# Altium schematic sheet Sheet_2.schdoc
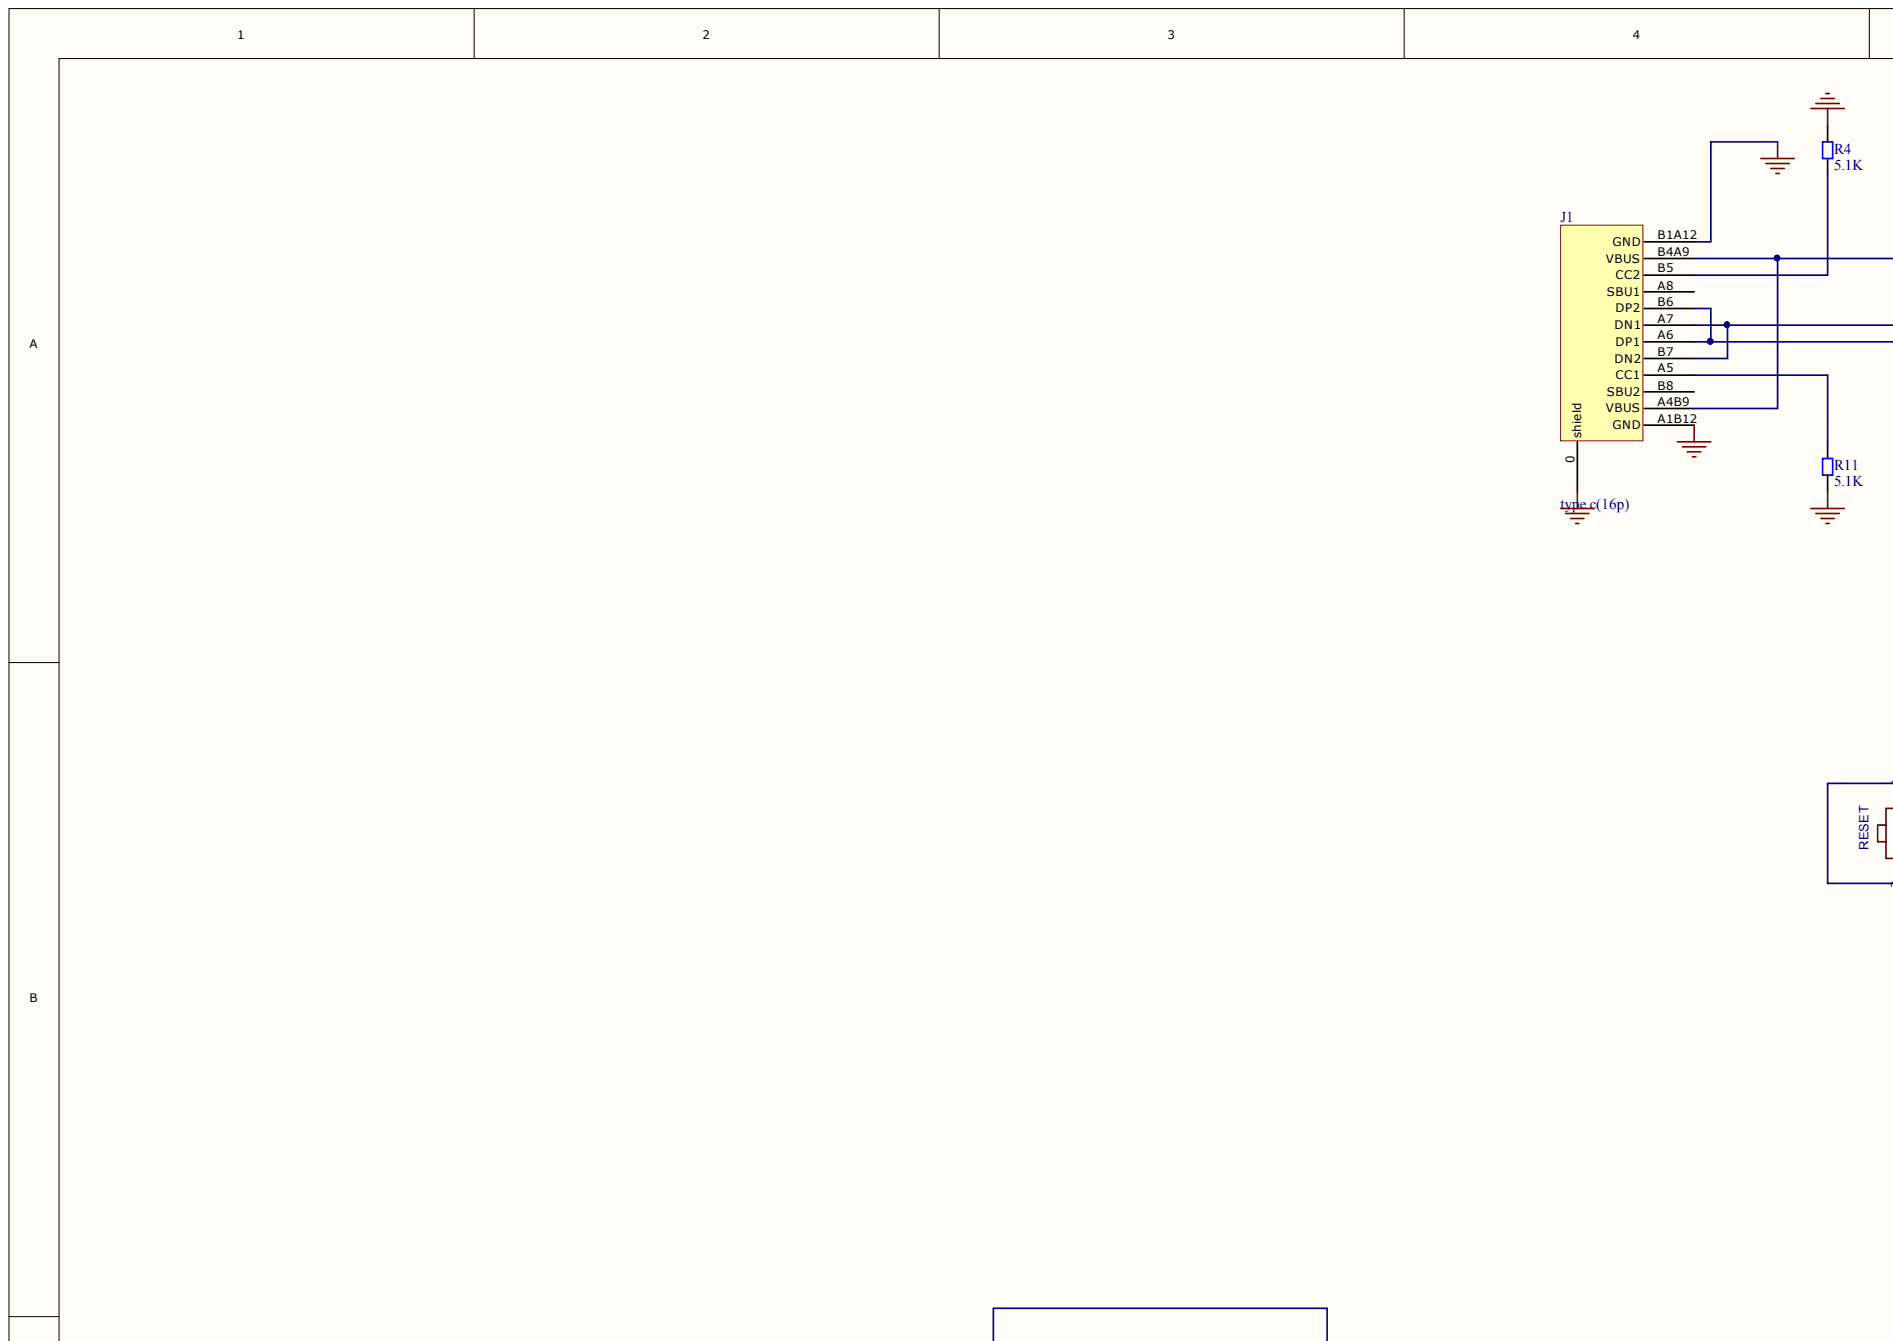
[diagram p0: Sheet_2.schdoc, top left]
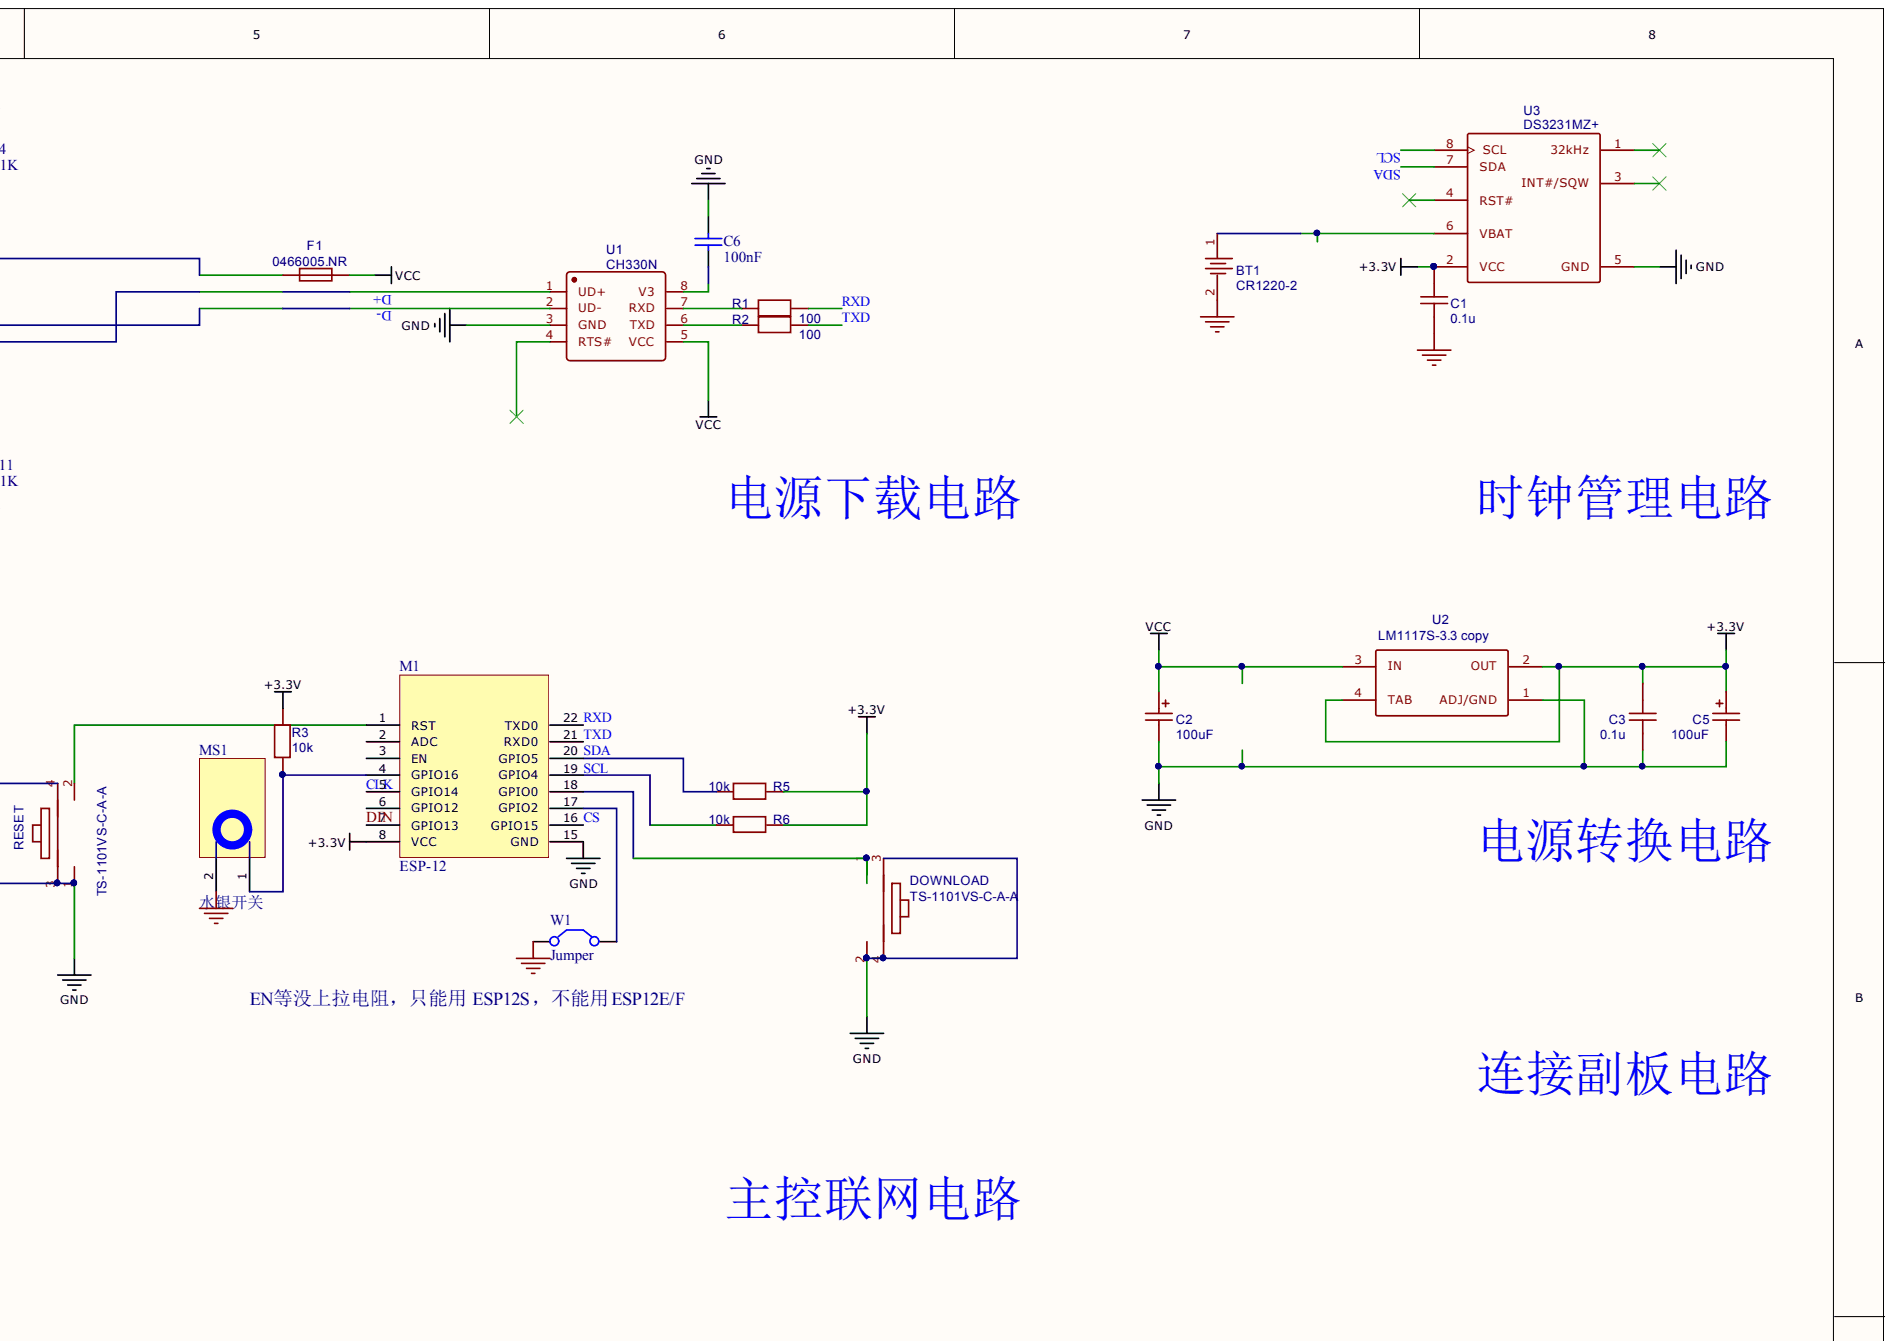
[diagram p1: Sheet_2.schdoc, top right]
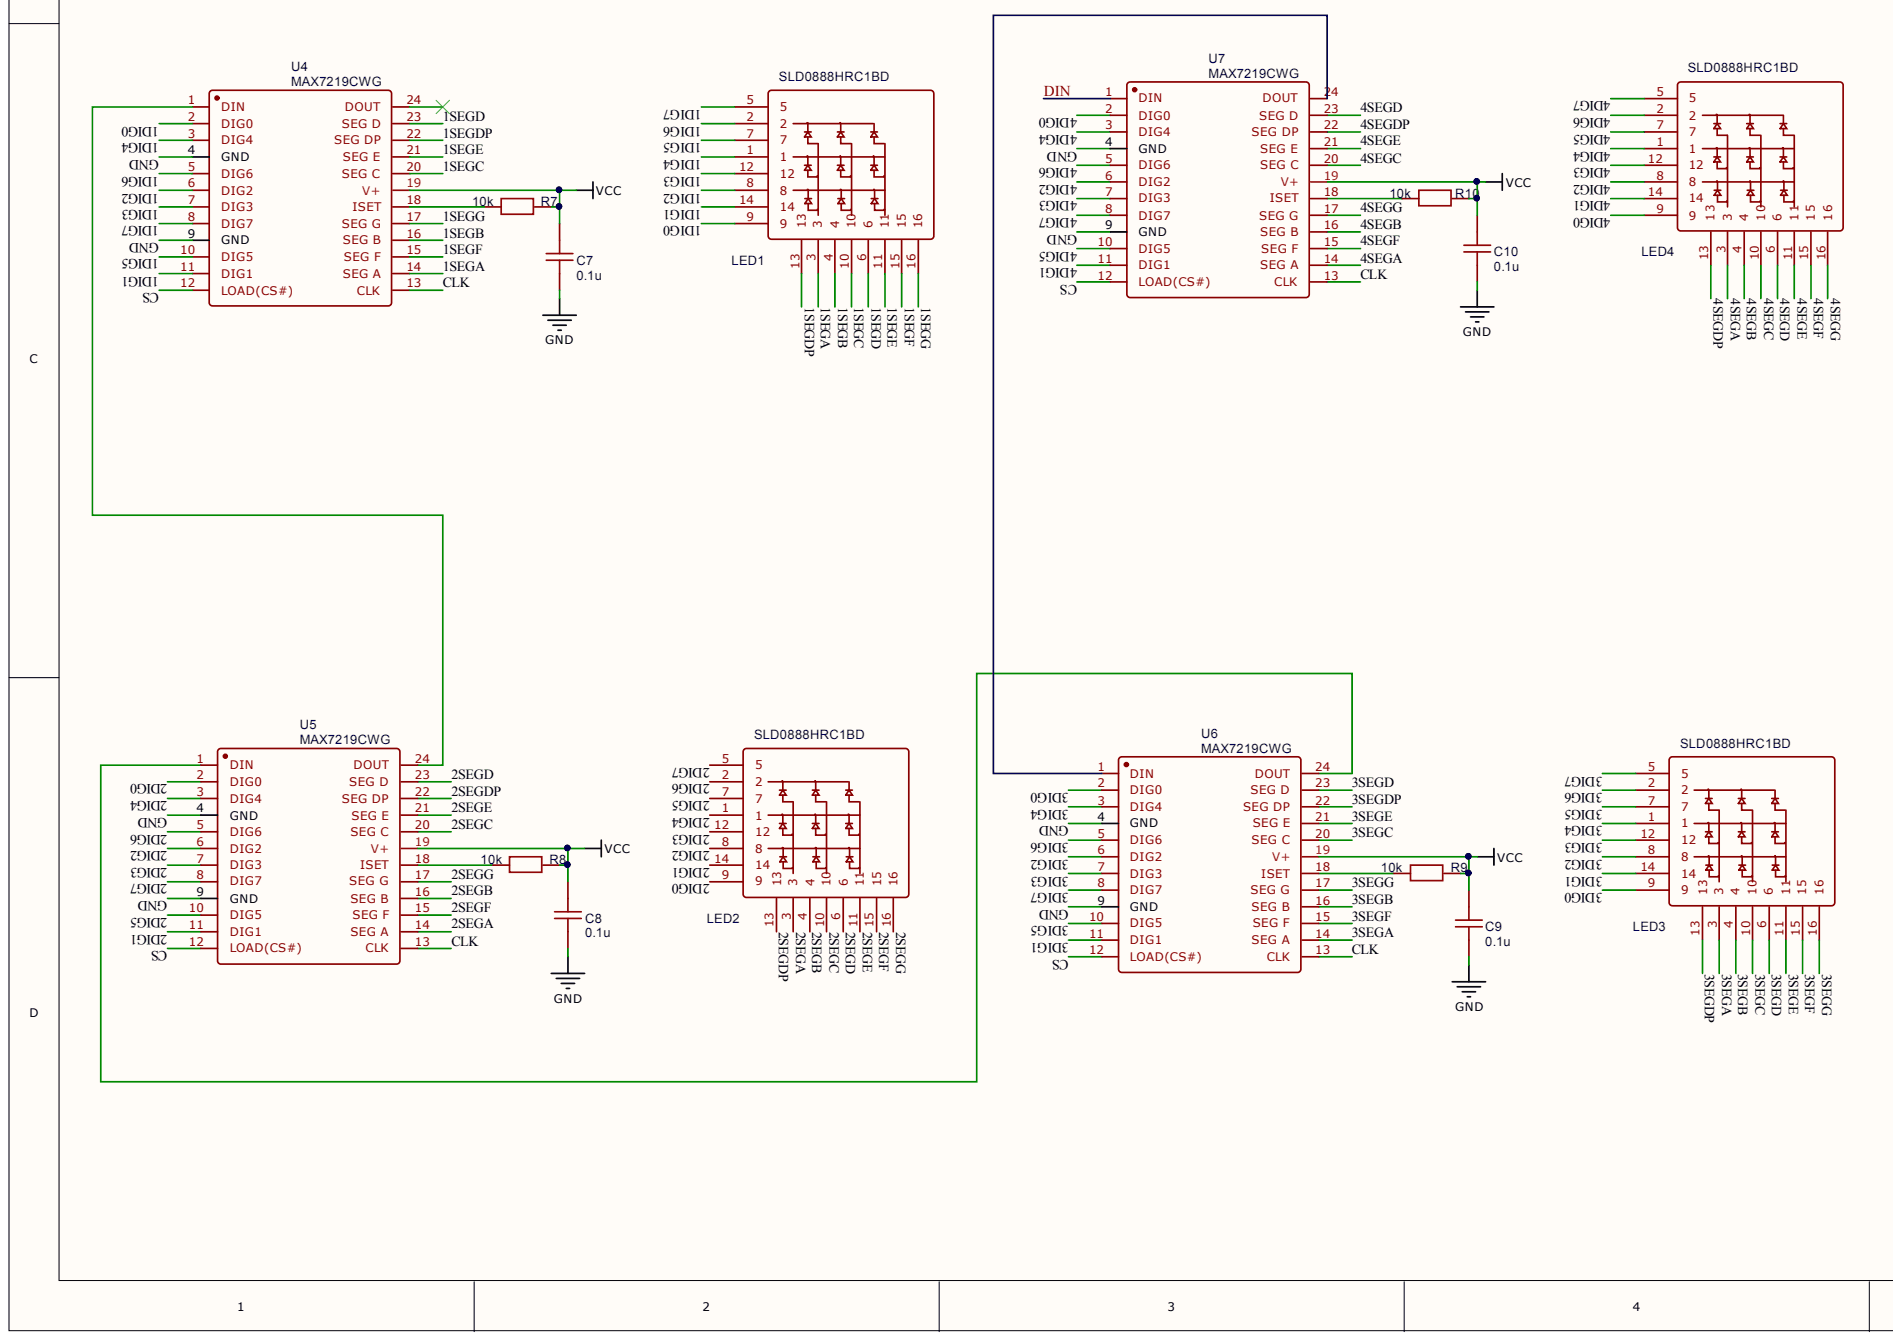
[diagram p2: Sheet_2.schdoc, bottom left]
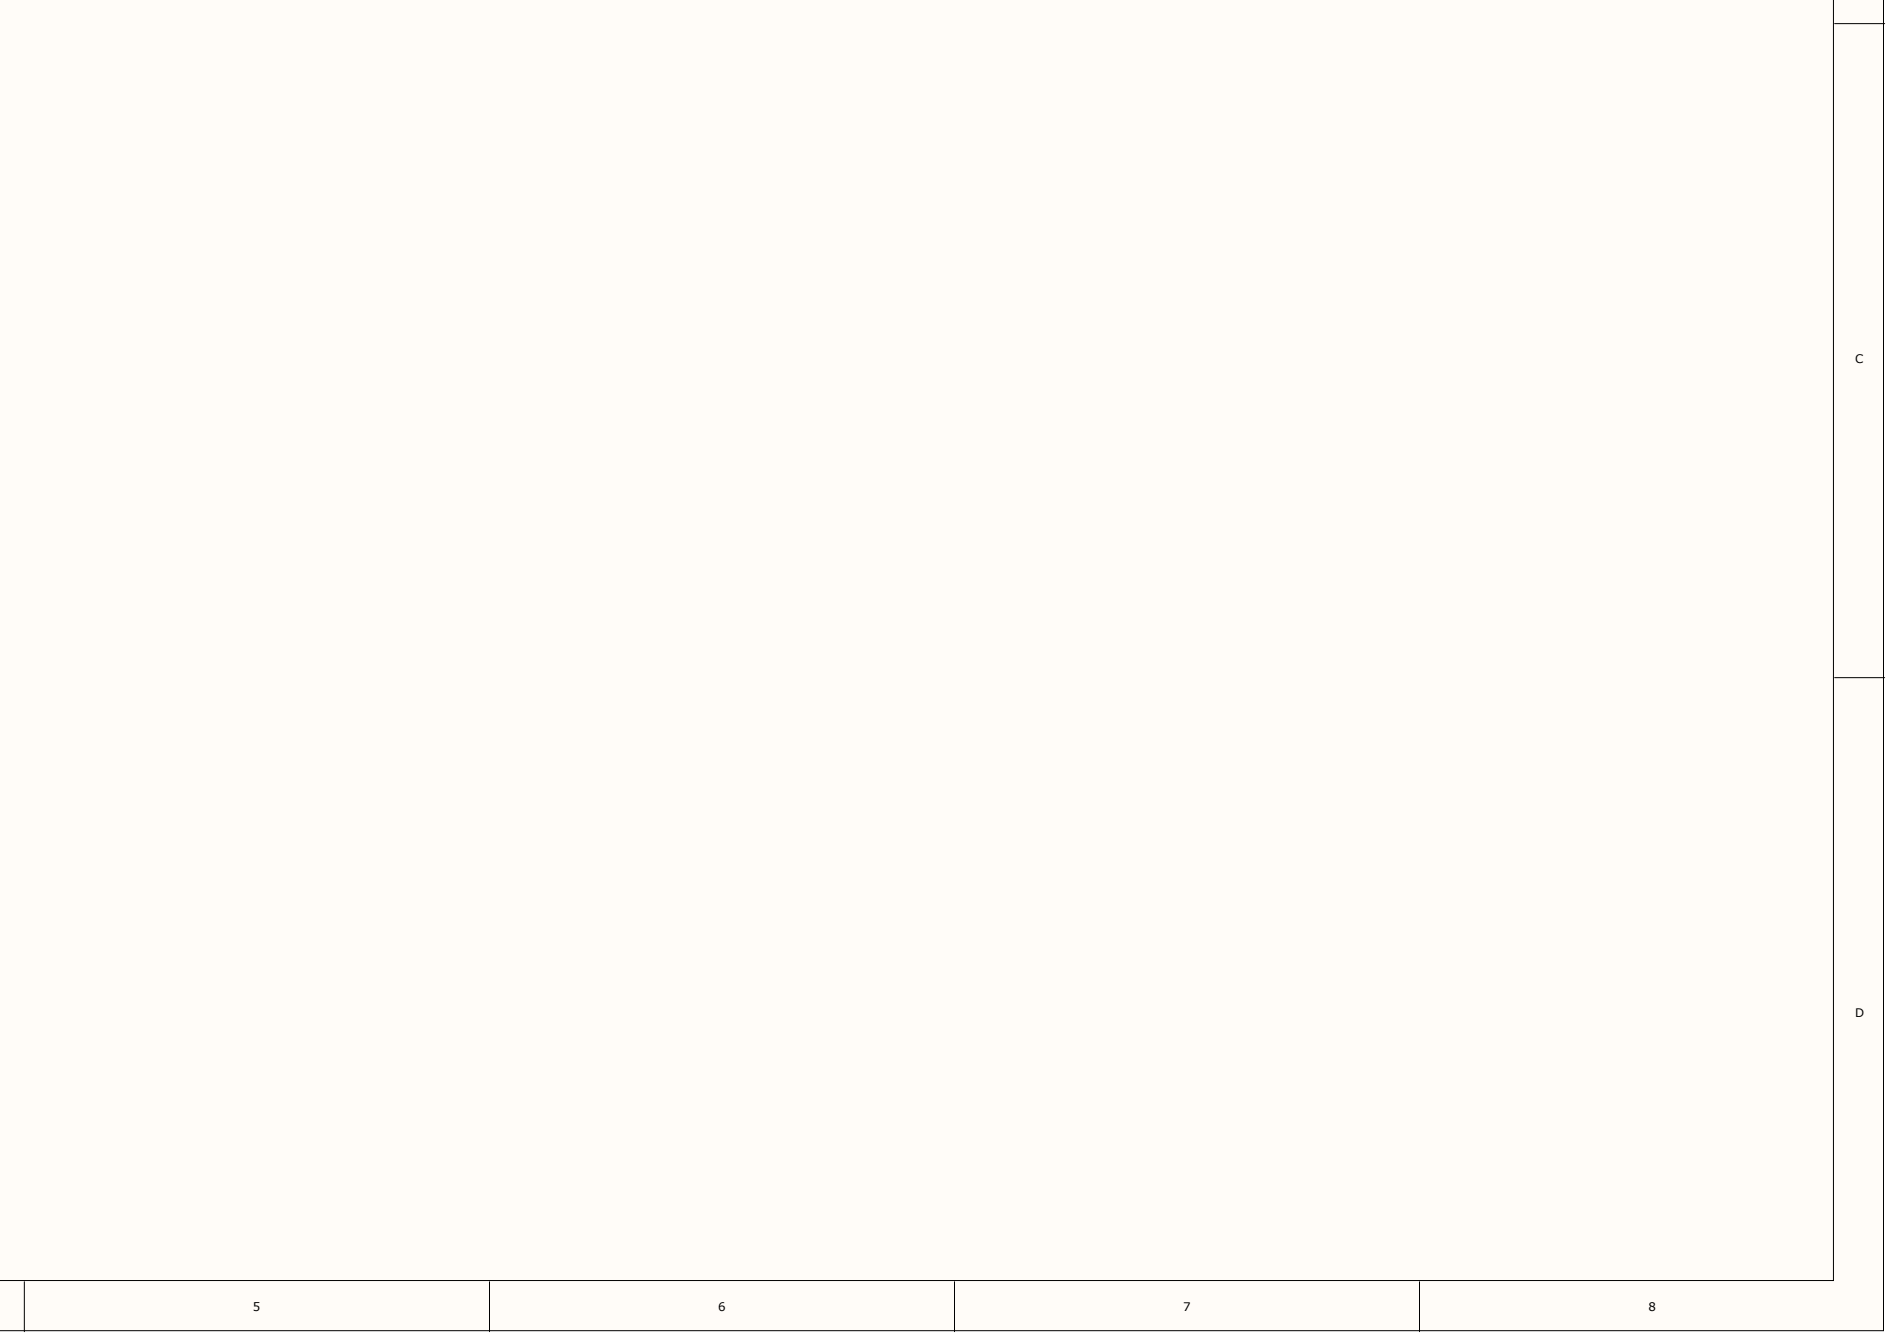
[diagram p3: Sheet_2.schdoc, bottom right]

## Parts
BT1  CR1220-2  [CR1220座]
C1  0.1u  [0603(RC1608)]
C2  100uF  [6mm贴片电解]
C3  0.1u  [0603(RC1608)]
C5  100uF  [0805(RC2012)]
C6  value 100nF  [0603(RC1608)]  (hidden comment: Cap)
C7  0.1u  [0603(RC1608)]
C8  0.1u  [0603(RC1608)]
C9  0.1u  [0603(RC1608)]
C10  0.1u  [0603(RC1608)]
DOWNLOAD  TS-1101VS-C-A-A  [KEY-SMD_4P-L7.8-W2.8-P4.20-EH]
F1  0466005.NR  [LC-1206_R]
J1  type c(16p)  [Type C(16P)]
LED1  SLD0888HRC1BD  [F3.0 LED DOT MATRIX]
LED2  SLD0888HRC1BD  [F3.0 LED DOT MATRIX]
LED3  SLD0888HRC1BD  [F3.0 LED DOT MATRIX]
LED4  SLD0888HRC1BD  [F3.0 LED DOT MATRIX]
M1  ESP-12  [ESP-12F贴片简化]
MS1  水银开关  [水银开关2]
R1  100  [0603(RC1608)]
R2  100  [0603(RC1608)]
R3  10k  [0603(RC1608)]
R4  5.1K  [0603(RC1608)]
R5  10k  [0603(RC1608)]
R6  10k  [0603(RC1608)]
R7  10k  [0603(RC1608)]
R8  10k  [0603(RC1608)]
R9  10k  [0603(RC1608)]
R10  10k  [0603(RC1608)]
R11  5.1K  [0603(RC1608)]
RESET  TS-1101VS-C-A-A  [KEY-SMD_4P-L7.8-W2.8-P4.20-EH]
U1  CH330N  [LC-SOP-8_150mil]
U2  LM1117S-3.3 copy  BOM_Manufacturer Part: LM1117S-3.3  [SOT-223]
U3  DS3231MZ+  [LC-SOP-8_150mil]
U4  MAX7219CWG  [SOIC-24_L15.4-W7.5-P1.27-LS10.3-BL]
U5  MAX7219CWG  [SOIC-24_L15.4-W7.5-P1.27-LS10.3-BL]
U6  MAX7219CWG  [SOIC-24_L15.4-W7.5-P1.27-LS10.3-BL]
U7  MAX7219CWG  [SOIC-24_L15.4-W7.5-P1.27-LS10.3-BL]
W1  Jumper  [跳线焊盘]

## Nets
+3.3V: C1.2 C3.2 C5.1 M1.8(VCC) R3.2 R5.2 R6.2 U2.2(OUT) U2.4(TAB) U3.2(VCC)
VCC: C2.1 C7.1 C8.1 C9.1 C10.1 F1.2 R7.2 R8.2 R9.2 R10.2 U1.5(VCC) U2.3(IN) U4.19(V+) U5.19(V+) U6.19(V+) U7.19(V+)
1DIG0: LED1.9 U4.2(DIG0)
1DIG1: LED1.14 U4.11(DIG1)
1DIG2: LED1.8 U4.6(DIG2)
1DIG3: LED1.12 U4.7(DIG3)
1DIG4: LED1.1 U4.3(DIG4)
1DIG5: LED1.7 U4.10(DIG5)
1DIG6: LED1.2 U4.5(DIG6)
1DIG7: LED1.5 U4.8(DIG7)
1SEGA: LED1.3 U4.14(SEG_A)
1SEGB: LED1.4 U4.16(SEG_B)
1SEGC: LED1.10 U4.20(SEG_C)
1SEGD: LED1.6 U4.23(SEG_D)
1SEGDP: LED1.13 U4.22(SEG_DP)
1SEGE: LED1.11 U4.21(SEG_E)
1SEGF: LED1.15 U4.15(SEG_F)
1SEGG: LED1.16 U4.17(SEG_G)
2DIG0: LED2.9 U5.2(DIG0)
2DIG1: LED2.14 U5.11(DIG1)
2DIG2: LED2.8 U5.6(DIG2)
2DIG3: LED2.12 U5.7(DIG3)
2DIG4: LED2.1 U5.3(DIG4)
2DIG5: LED2.7 U5.10(DIG5)
2DIG6: LED2.2 U5.5(DIG6)
2DIG7: LED2.5 U5.8(DIG7)
2SEGA: LED2.3 U5.14(SEG_A)
2SEGB: LED2.4 U5.16(SEG_B)
2SEGC: LED2.10 U5.20(SEG_C)
2SEGD: LED2.6 U5.23(SEG_D)
2SEGDP: LED2.13 U5.22(SEG_DP)
2SEGE: LED2.11 U5.21(SEG_E)
2SEGF: LED2.15 U5.15(SEG_F)
2SEGG: LED2.16 U5.17(SEG_G)
3DIG0: LED3.9 U6.2(DIG0)
3DIG1: LED3.14 U6.11(DIG1)
3DIG2: LED3.8 U6.6(DIG2)
3DIG3: LED3.12 U6.7(DIG3)
3DIG4: LED3.1 U6.3(DIG4)
3DIG5: LED3.7 U6.10(DIG5)
3DIG6: LED3.2 U6.5(DIG6)
3DIG7: LED3.5 U6.8(DIG7)
3SEGA: LED3.3 U6.14(SEG_A)
3SEGB: LED3.4 U6.16(SEG_B)
3SEGC: LED3.10 U6.20(SEG_C)
3SEGD: LED3.6 U6.23(SEG_D)
3SEGDP: LED3.13 U6.22(SEG_DP)
3SEGE: LED3.11 U6.21(SEG_E)
3SEGF: LED3.15 U6.15(SEG_F)
3SEGG: LED3.16 U6.17(SEG_G)
4DIG0: LED4.9 U7.2(DIG0)
4DIG1: LED4.14 U7.11(DIG1)
4DIG2: LED4.8 U7.6(DIG2)
4DIG3: LED4.12 U7.7(DIG3)
4DIG4: LED4.1 U7.3(DIG4)
4DIG5: LED4.7 U7.10(DIG5)
4DIG6: LED4.2 U7.5(DIG6)
4DIG7: LED4.5 U7.8(DIG7)
4SEGA: LED4.3 U7.14(SEG_A)
4SEGB: LED4.4 U7.16(SEG_B)
4SEGC: LED4.10 U7.20(SEG_C)
4SEGD: LED4.6 U7.23(SEG_D)
4SEGDP: LED4.13 U7.22(SEG_DP)
4SEGE: LED4.11 U7.21(SEG_E)
4SEGF: LED4.15 U7.15(SEG_F)
4SEGG: LED4.16 U7.17(SEG_G)
CLK: M1.5(GPIO14) U4.13(CLK) U5.13(CLK) U6.13(CLK) U7.13(CLK)
CS: M1.16(GPIO15) U4.12(LOAD(CS#)) U5.12(LOAD(CS#)) U6.12(LOAD(CS#)) U7.12(LOAD(CS#))
D+: J1.A6(DP1) J1.B6(DP2) U1.1(UD+)
D-: J1.A7(DN1) J1.B7(DN2) U1.2(UD-)
DIN: M1.7(GPIO13) U7.1(DIN)
GND: BT1.2 C1.1 C2.2 C3.1 C5.2 C6.2 C7.2 C8.2 C9.2 C10.2 DOWNLOAD.2 DOWNLOAD.3 DOWNLOAD.4 J1.0(shield) J1.A1B12(GND) J1.B1A12(GND) M1.15(GND) MS1.2 R4.2 R11.1 RESET.1 RESET.3 RESET.4 U1.3(GND) U2.1(ADJ/GND) U3.5(GND) U4.4(GND) U4.9(GND) U5.4(GND) U5.9(GND) U6.4(GND) U6.9(GND) U7.4(GND) U7.9(GND) W1.1
RXD: M1.22(TXD0) R1.2
SCL: M1.19(GPIO4) R6.1 U3.8(SCL)
SDA: M1.20(GPIO5) R5.1 U3.7(SDA)
TXD: M1.21(RXD0) R2.2
NetBT1_1: BT1.1 U3.6(VBAT)
NetC6_1: C6.1 U1.8(V3)
NetDOWNLOAD_1: DOWNLOAD.1 M1.18(GPIO0)
NetF1_1: F1.1 J1.A4B9(VBUS) J1.B4A9(VBUS)
NetJ1_A5: J1.A5(CC1) R11.2
NetJ1_B5: J1.B5(CC2) R4.1
NetM1_1: M1.1(RST) RESET.2
NetM1_4: M1.4(GPIO16) MS1.1 R3.1
NetM1_17: M1.17(GPIO2) W1.2
NetR1_1: R1.1 U1.7(RXD)
NetR2_1: R2.1 U1.6(TXD)
NetR7_1: R7.1 U4.18(ISET)
NetR8_1: R8.1 U5.18(ISET)
NetR9_1: R9.1 U6.18(ISET)
NetR10_1: R10.1 U7.18(ISET)
NetU4_1: U4.1(DIN) U5.24(DOUT)
NetU5_1: U5.1(DIN) U6.24(DOUT)
NetU6_1: U6.1(DIN) U7.24(DOUT)

Not connected: J1 A8(SBU1) B8(SBU2); M1 2(ADC) 3(EN) 6(GPIO12); U1 4(RTS#); U3 1(32kHz) 3(INT#/SQW) 4(RST#); U4 24(DOUT)

## Library data of the parts
BT1 = CR1220-2: BOM_Manufacturer: Q&J; BOM_Manufacturer Part: CR1220-2; BOM_Supplier: LCSC; BOM_Supplier Part: C70381; Component Kind: Standard; image: //image.lceda.cn/szlcsc/C70381.jpg; spicePre: B; spiceSymbolName: CR1220-2
C1 C3 C7-C10 = 0.1u: Contributor: LCEDA_Lib; nameAlias: Value(F); Component Kind: Standard; spicePre: C; spiceSymbolName: C_0603_US
C2 C5 = 100uF: BOM_Manufacturer: AVX; BOM_Manufacturer Part: TAJB107M010RNJ; nameAlias: Capacitance; SMT Type: Extend; BOM_LCSC Assembly: Yes; BOM_Supplier: LCSC; BOM_Supplier Part: C7196; Component Kind: Standard; spicePre: C; spiceSymbolName: TAJB107M010RNJ
C6 = Cap: Capacitor; Component Kind: Standard; LatestRevisionDate: 17-Jul-2002; LatestRevisionNote: Re-released for DXP Platform.; PackageDescription: Radial Cap, Thru-Hole; 2 Leads; 0.3 in Pin Spacing; PackageReference: RAD-0.3; Published: 8-Jun-2000; Signal Integrity: Cap; Simulation: CAP; Library Name: 我的.SCHLIB; Publisher: Altium Limited
DOWNLOAD RESET = TS-1101VS-C-A-A: BOM_Manufacturer: XKB Enterprise; Contributor: LCSC; BOM_Manufacturer Part: TS-1101VS-C-A-A; BOM_Supplier: LCSC; BOM_Supplier Part: C318936; Component Kind: Standard; spicePre: S; spiceSymbolName: TS-1101VS-C-A-A
F1 = 0466005.NR: BOM_Manufacturer: Littelfuse; Contributor: LCSC; SMT Type: Extend; BOM_LCSC Assembly: Yes; BOM_Manufacturer Part: 0466005.NR; BOM_Supplier: LCSC; BOM_Supplier Part: C187597; Component Kind: Standard; spicePre: U; spiceSymbolName: 0466005.NR
LED1-LED4 = SLD0888HRC1BD: BOM_Manufacturer: SUNLIGHT; Contributor: LCSC; BOM_Manufacturer Part: SLD0888HRC1BD; BOM_Supplier: LCSC; BOM_Supplier Part: C225985; Component Kind: Standard; spicePre: L; spiceSymbolName: SLD0888HRC1BD
R1 R2 = 100: Contributor: LCEDA_Lib; nameAlias: Value(Ω); Component Kind: Standard; spicePre: R; spiceSymbolName: R_0603_EU
R3 R5-R10 = 10k: Contributor: LCEDA_Lib; nameAlias: Value(Ω); Component Kind: Standard; spicePre: R; spiceSymbolName: R_0603_EU
R4 R11 = RES2: Component Kind: Standard; Library Name: Miscellaneous Devices.SCHLIB
U1 = CH330N: BOM_Manufacturer: WCH; BOM_Manufacturer Part: CH330N; BOM_Supplier: LCSC; BOM_Supplier Part: C108996; Component Kind: Standard; spicePre: U; spiceSymbolName: CH330N
U2 = LM1117S-3.3 copy: BOM_Manufacturer: HTC; SMT Type: Extend; BOM_LCSC Assembly: Yes; BOM_Supplier: LCSC; BOM_Supplier Part: C126027; Component Kind: Standard; spicePre: U
U3 = DS3231MZ+: BOM_Manufacturer: MAXIM; SMT Type: Extend; BOM_LCSC Assembly: Yes; BOM_Manufacturer Part: DS3231MZ+; BOM_Supplier: LCSC; BOM_Supplier Part: C107410; Component Kind: Standard; spicePre: U; spiceSymbolName: DS3231MZ+
U4-U7 = MAX7219CWG: BOM_Manufacturer: MAXIM; SMT Type: Extend; BOM_LCSC Assembly: Yes; BOM_Manufacturer Part: MAX7219CWG; BOM_Supplier: LCSC; BOM_Supplier Part: C9964; Component Kind: Standard; image: //image.lceda.cn/szlcsc/C9964.jpg; spicePre: U; spiceSymbolName: MAX7219CWG
W1 = Jumper: Jumper Wire; Component Kind: Standard; LatestRevisionDate: 17-Jul-2002; LatestRevisionNote: Re-released for DXP Platform.; Library Name: Miscellaneous Devices.IntLib; PackageDescription: Radial Cap, Thru-Hole; 2 Leads; 0.2 in Pin Spacing; PackageReference: RAD-0.2; Published: 24-Mar-1999; Publisher: Altium Limited
J1 = type c(16p): Component Kind: Standard; Library Name: 我的.SCHLIB
M1 = ESP-12: Component Kind: Standard; Library Name: 我的.SCHLIB
MS1 = 水银开关: Component Kind: Standard; Library Name: 我的.SCHLIB
